# Altium schematic sheet Sensor inputs.SchDoc("Sensor inputs")
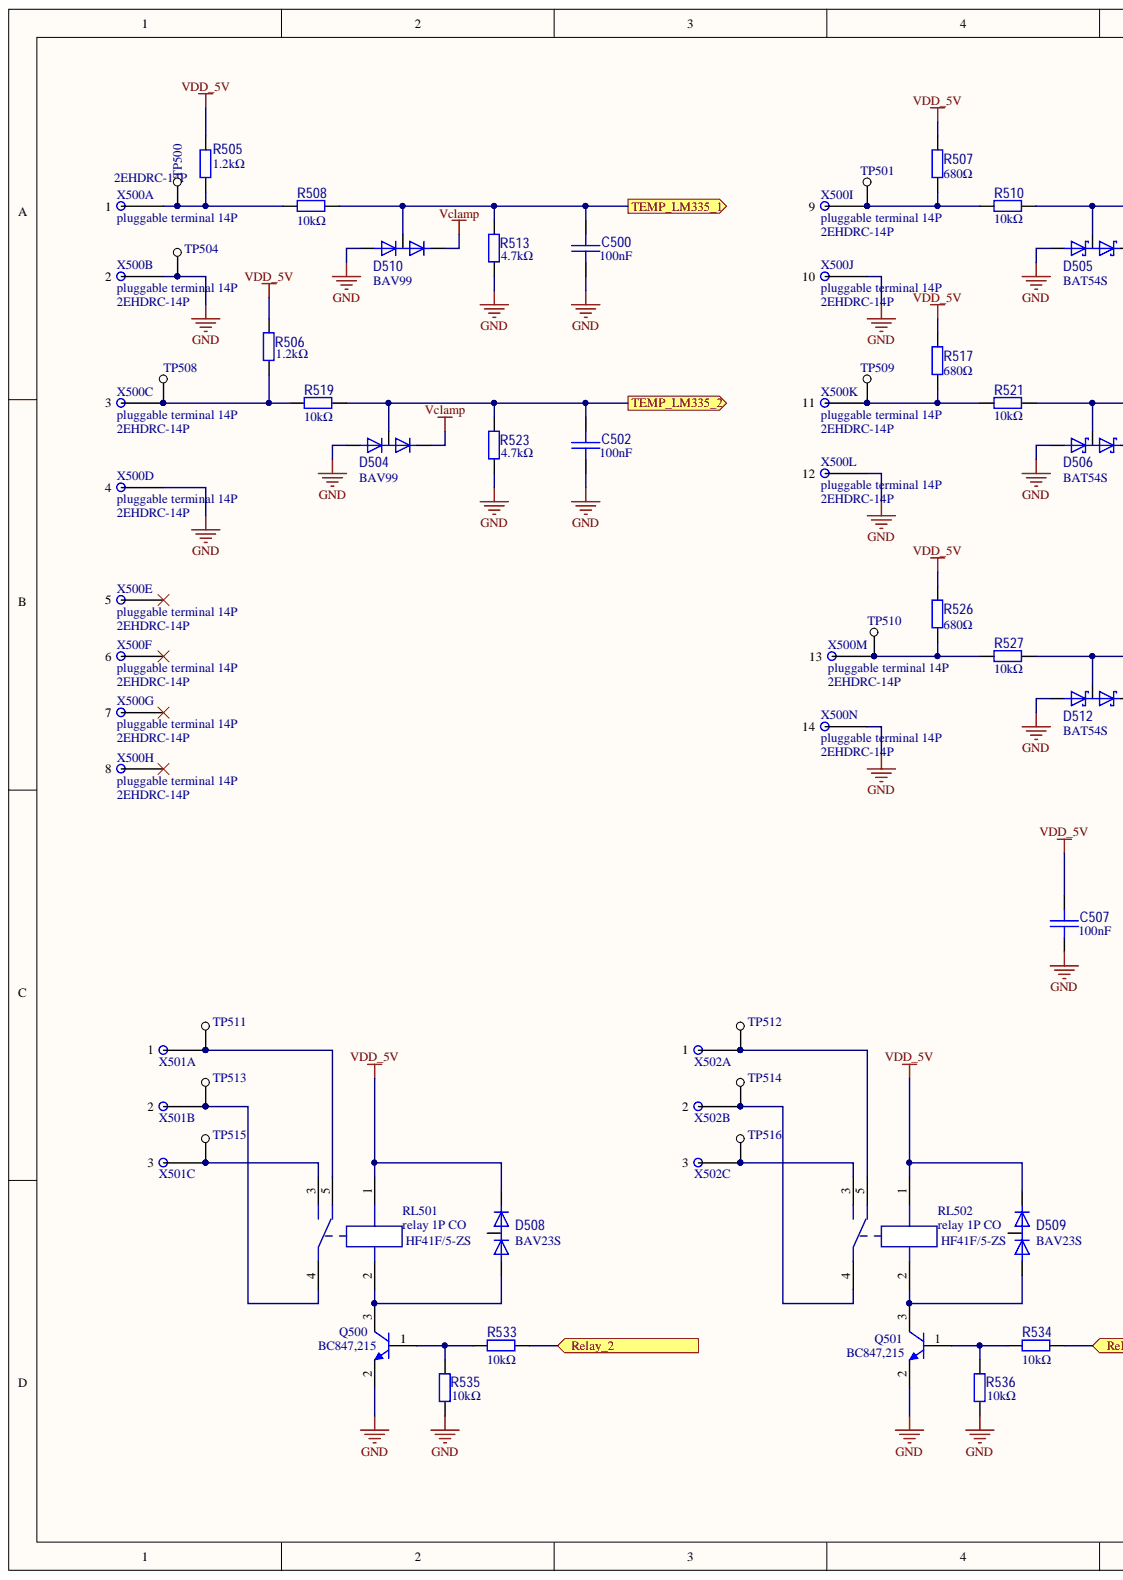
[diagram p0: Sensor inputs.SchDoc, left]
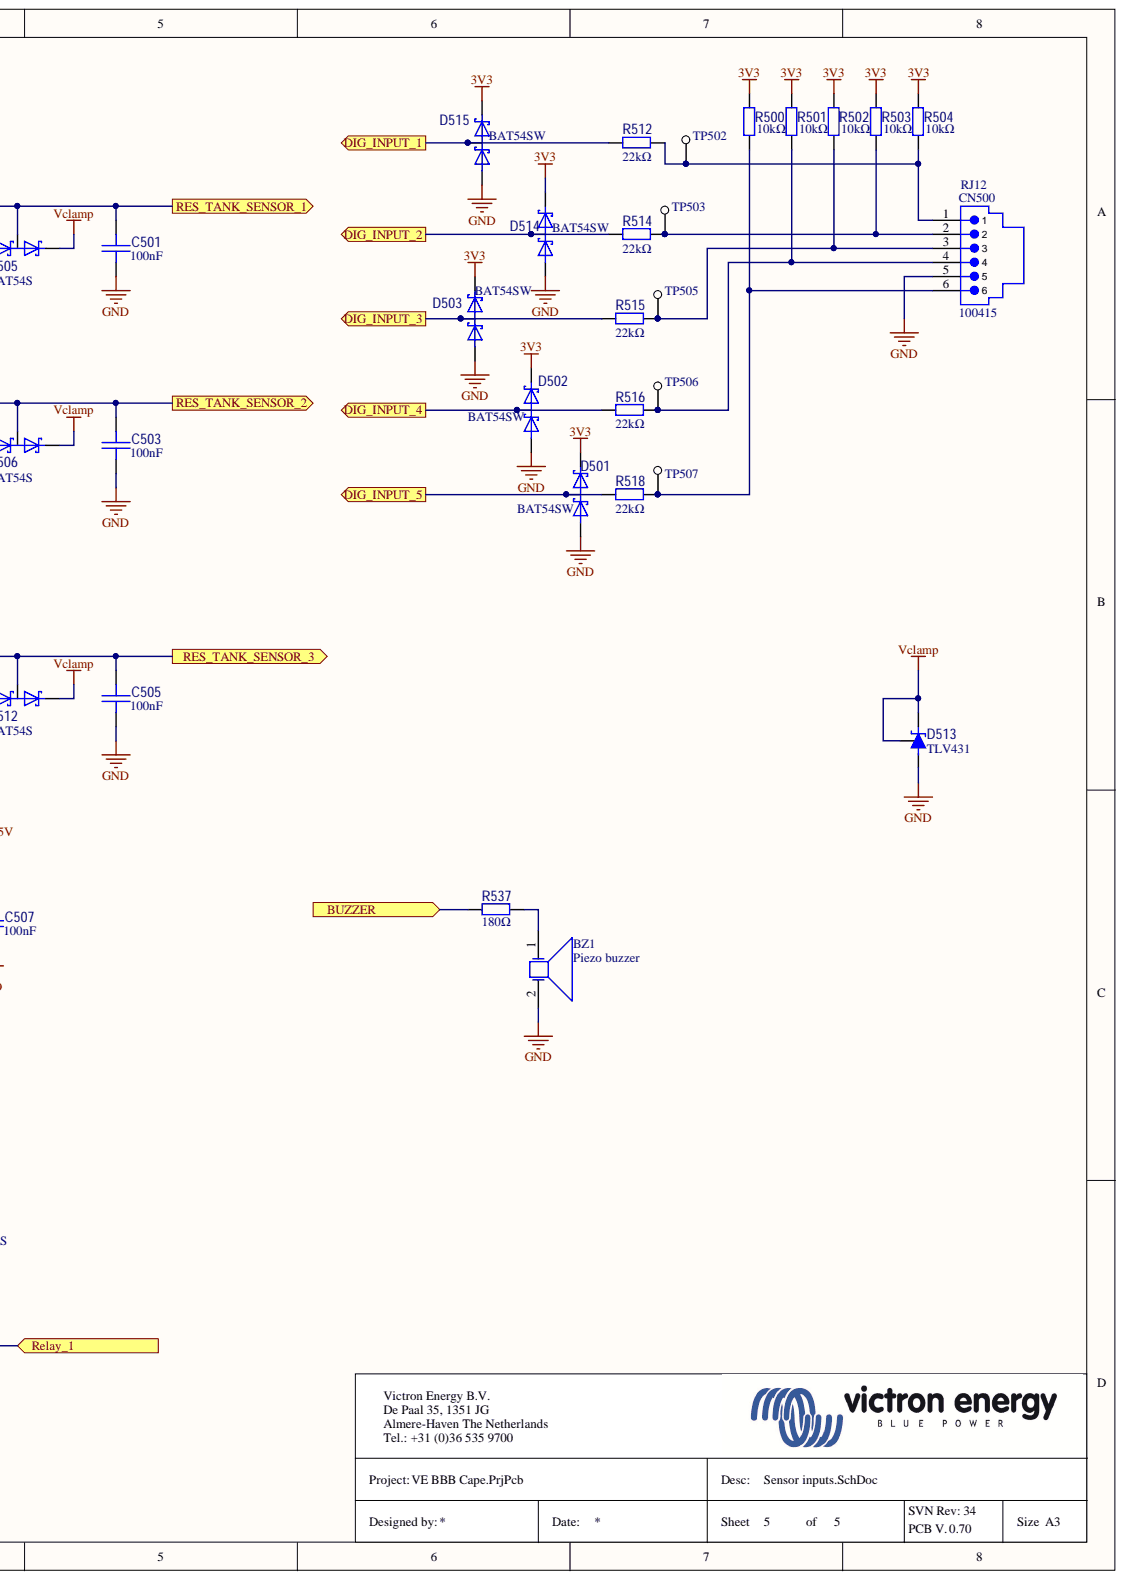
[diagram p1: Sensor inputs.SchDoc, right]

## Parts
BZ1  Piezo buzzer  [TH_BUZ_12.5mm]
C500  value 100nF  [C0805_R]  (hidden comment: 1035)
C501  value 100nF  [C0805_R]  (hidden comment: 1035)
C502  value 100nF  [C0805_R]  (hidden comment: 1035)
C503  value 100nF  [C0805_R]  (hidden comment: 1035)
C505  value 100nF  [C0805_R]  (hidden comment: 1035)
C507  value 100nF  [C0805_R]  (hidden comment: 1035)
CN500  100415  value RJ12  [Molex950012661]
D501  MAN_PARTNUMBER: BAT54SW  [SOT323R]  (hidden comment: Value)
D502  MAN_PARTNUMBER: BAT54SW  [SOT323R]  (hidden comment: Value)
D503  MAN_PARTNUMBER: BAT54SW  [SOT323R]  (hidden comment: Value)
D504  BAV99  [SOT23_R]
D505  BAT54S  [SOT23_R]
D506  BAT54S  [SOT23_R]
D508  BAV23S  [SOT23_R]
D509  BAV23S  [SOT23_R]
D510  BAV99  [SOT23_R]
D512  BAT54S  [SOT23_R]
D513  TLV431  [SOT23_R]
D514  MAN_PARTNUMBER: BAT54SW  [SOT323R]  (hidden comment: Value)
D515  MAN_PARTNUMBER: BAT54SW  [SOT323R]  (hidden comment: Value)
Q500  value BC847,215  [SOT23_R]  (hidden comment: 100435)
Q501  value BC847,215  [SOT23_R]  (hidden comment: 100435)
R500  value 10kΩ  [R0805_R]  (hidden comment: 396)
R501  value 10kΩ  [R0805_R]  (hidden comment: 396)
R502  value 10kΩ  [R0805_R]  (hidden comment: 396)
R503  value 10kΩ  [R0805_R]  (hidden comment: 396)
R504  value 10kΩ  [R0805_R]  (hidden comment: 396)
R505  value 1.2kΩ  [R0805_R]  (hidden comment: 374)
R506  value 1.2kΩ  [R0805_R]  (hidden comment: 374)
R507  value 680Ω  [R0805_R]  (hidden comment: 368)
R508  value 10kΩ  [R0805_R]  (hidden comment: 396)
R510  value 10kΩ  [R0805_R]  (hidden comment: 396)
R512  value 22kΩ  [R0805_R]  (hidden comment: 404)
R513  value 4.7kΩ  [R0805_R]  (hidden comment: 384)
R514  value 22kΩ  [R0805_R]  (hidden comment: 404)
R515  value 22kΩ  [R0805_R]  (hidden comment: 404)
R516  value 22kΩ  [R0805_R]  (hidden comment: 404)
R517  value 680Ω  [R0805_R]  (hidden comment: 368)
R518  value 22kΩ  [R0805_R]  (hidden comment: 404)
R519  value 10kΩ  [R0805_R]  (hidden comment: 396)
R521  value 10kΩ  [R0805_R]  (hidden comment: 396)
R523  value 4.7kΩ  [R0805_R]  (hidden comment: 384)
R526  value 680Ω  [R0805_R]  (hidden comment: 368)
R527  value 10kΩ  [R0805_R]  (hidden comment: 396)
R533  value 10kΩ  [R0805_R]  (hidden comment: 396)
R534  value 10kΩ  [R0805_R]  (hidden comment: 396)
R535  value 10kΩ  [R0805_R]  (hidden comment: 396)
R536  value 10kΩ  [R0805_R]  (hidden comment: 396)
R537  value 180Ω  [R0805_R]  (hidden comment: 354)
RL501  relay 1P CO  value HF41F/5-ZS  VENDOR_STOCK_NR: HF41F/5-ZS  [PNSC]
RL502  relay 1P CO  value HF41F/5-ZS  [PNSC]
TP500  CN1  [testpad_round_1mm]  (value not drawn)  (no BOM)
TP501  CN1  [testpad_round_1mm]  (value not drawn)  (no BOM)
TP502  CN1  [testpad_round_1mm]  (value not drawn)  (no BOM)
TP503  CN1  [testpad_round_1mm]  (value not drawn)  (no BOM)
TP504  CN1  [testpad_round_1mm]  (value not drawn)  (no BOM)
TP505  CN1  [testpad_round_1mm]  (value not drawn)  (no BOM)
TP506  CN1  [testpad_round_1mm]  (value not drawn)  (no BOM)
TP507  CN1  [testpad_round_1mm]  (value not drawn)  (no BOM)
TP508  CN1  [testpad_round_1mm]  (value not drawn)  (no BOM)
TP509  CN1  [testpad_round_1mm]  (value not drawn)  (no BOM)
TP510  CN1  [testpad_round_1mm]  (value not drawn)  (no BOM)
TP511  CN1  [testpad_round_1mm]  (value not drawn)  (no BOM)
TP512  CN1  [testpad_round_1mm]  (value not drawn)  (no BOM)
TP513  CN1  [testpad_round_1mm]  (value not drawn)  (no BOM)
TP514  CN1  [testpad_round_1mm]  (value not drawn)  (no BOM)
TP515  CN1  [testpad_round_1mm]  (value not drawn)  (no BOM)
TP516  CN1  [testpad_round_1mm]  (value not drawn)  (no BOM)
X500  pluggable terminal 14P  value 2EHDRC-14P  [2EHDRC-14P]  (parts A,B,C,D,E,F,G,H,I,J,K,L,M,N)
X501  pluggable terminal 3P  [2EHDRC-3P]  (value not drawn)  (parts A,B,C)
X502  pluggable terminal 3P  [2EHDRC-3P]  (value not drawn)  (parts A,B,C)

## Ports
BUZZER, DIG_INPUT_1, DIG_INPUT_2, DIG_INPUT_3, DIG_INPUT_4, DIG_INPUT_5, Relay_1, Relay_2, RES_TANK_SENSOR_1, RES_TANK_SENSOR_2, RES_TANK_SENSOR_3, TEMP_LM335_1, TEMP_LM335_2

## Nets
3V3: D501.2 D502.2 D503.2 D514.2 D515.2 R500.2 R501.2 R502.2 R503.2 R504.2
GND: BZ1.2 C500.2 C501.2 C502.2 C503.2 C505.2 C507.2 CN500.5 D501.1 D502.1 D503.1 D504.1 D505.1 D506.1 D510.1 D512.1 D513.3 D514.1 D515.1 Q500.2 Q501.2 R513.2 R523.2 R535.1 R536.1 TP504.1 X500.2 X500.4 X500.10 X500.12 X500.14
Vclamp: D504.2 D505.2 D506.2 D510.2 D512.2 D513.1 D513.2
VDD_5V: C507.1 D508.2 D509.2 R505.1 R506.1 R507.1 R517.1 R526.1 RL501.1 RL502.1
BUZZER: R537.1 ; port BUZZER
DIG_INPUT_1: D515.3 R512.1 ; port DIG_INPUT_1
DIG_INPUT_2: D514.3 R514.1 ; port DIG_INPUT_2
DIG_INPUT_3: D503.3 R515.1 ; port DIG_INPUT_3
DIG_INPUT_4: D502.3 R516.1 ; port DIG_INPUT_4
DIG_INPUT_5: D501.3 R518.1 ; port DIG_INPUT_5
Relay_1: R534.2 ; port Relay_1
Relay_2: R533.2 ; port Relay_2
RES_TANK_SENSOR_1: C501.1 D505.3 R510.2 ; port RES_TANK_SENSOR_1
RES_TANK_SENSOR_2: C503.1 D506.3 R521.2 ; port RES_TANK_SENSOR_2
RES_TANK_SENSOR_3: C505.1 D512.3 R527.2 ; port RES_TANK_SENSOR_3
TEMP_LM335_1: C500.1 D510.3 R508.2 R513.1 ; port TEMP_LM335_1
TEMP_LM335_2: C502.1 D504.3 R519.2 R523.1 ; port TEMP_LM335_2
NetBZ1_1: BZ1.1 R537.2
NetCN500_1: CN500.1 R504.1 R512.2 TP502.1
NetCN500_2: CN500.2 R503.1 R514.2 TP503.1
NetCN500_3: CN500.3 R502.1 R515.2 TP505.1
NetCN500_4: CN500.4 R501.1 R516.2 TP506.1
NetCN500_6: CN500.6 R500.1 R518.2 TP507.1
NetD508_1: D508.1 Q500.3 RL501.2
NetD509_1: D509.1 Q501.3 RL502.2
NetQ500_1: Q500.1 R533.1 R535.2
NetQ501_1: Q501.1 R534.1 R536.2
NetR505_2: R505.2 R508.1 TP500.1 X500.1
NetR506_2: R506.2 R519.1 TP508.1 X500.3
NetR507_2: R507.2 R510.1 TP501.1 X500.9
NetR517_2: R517.2 R521.1 TP509.1 X500.11
NetR526_2: R526.2 R527.1 TP510.1 X500.13
NetRL501_3: RL501.3 TP515.1 X501.3
NetRL501_4: RL501.4 TP513.1 X501.2
NetRL501_5: RL501.5 TP511.1 X501.1
NetRL502_3: RL502.3 TP516.1 X502.3
NetRL502_4: RL502.4 TP514.1 X502.2
NetRL502_5: RL502.5 TP512.1 X502.1

Not connected: D508 3; D509 3; X500 5 6 7 8

## Library data of the parts
BZ1 = 101565: MANUFACTURER: Murata; MAN_PARTNUMBER: PKM13EPYH4000-A0; Database Table Name: Mechanical; Cls: MECH; Value: Piezo buzzer; Supplier Price 1: 0,267; Supplier Part Number 1: 490-4698-ND; Supplier 1: Digikey; PartID: 101565; Part: 101565; Description: Piezo buzzer; Component Kind: Standard; Library Name: VictronAltiumComponents.SVNDBLib
C500-C503 C505 C507 = 1035: Cap, SMD 0805, ceramic, X7R, 100nF, 50V, 10%, Yageo; MAN_PARTNUMBER: CC0805KPX7R9BB104; INDIC_PARTNO: CSE100NF0805YAGY; Tol: 10,00%; Shape: 805; INDIC_PRICE: 0,068; Database Table Name: AllComponents; Voltage: 50V; Supplier Price 1: 0,005; Supplier Part Number 1: 603-CC805KPX7R9BB104; Supplier 1: Mouser; PartID: 1035; Part: 1035; MANUFACTURER: Yageo; Component Kind: Standard; Cls: CAP; Library Name: VictronAltiumComponents.SVNDBLib
CN500 = 100415: RJ12; Part_Description: RJ12 Side entry; MAN_PARTNUMBER: 955012661; VENDOR_STOCK_NR: WM5570-ND; VENDOR_PRICE: 0.45312; VENDOR: Digikey; Database Table Name: COMPDATA$; Cls: CON; Part: 100415; Component Kind: Standard; Library Name: Data Base Librarys.DbLib
D501-D503 D514 D515 = 101810: Diode Schottky BAT54SW; MANUFACTURER: NXP; Shape: SOT323; Cls: DIO; Supplier Price 1: 0,03698; Supplier Part Number 1: 568-1615-2-ND; Supplier 1: Digikey; PartID: 101810; Part: 101810; Database Table Name: Diode; Component Kind: Standard; Library Name: VictronAltiumComponents.SVNDBLib
D504 D510 = 4001: Diode, SMD, BAV99; MANUFACTURER: Fairchild; IRRICHI_PARTNO: 2403327470000; INDIC_PARTNO: DDSANBAV99YN/XX; INCAP_PARTNO: 1009100528; Shape: SOT23; IRRICHI_PRICE: 0,0171; INDIC_PRICE: 0,026; INCAP_PRICE: 0,0153; Database Table Name: AllComponents; Cls: DIO; Value: BAV99; Supplier Price 1: 0,02091; Supplier Part Number 1: BAV99LT1GOSTR-ND; Supplier 1: Digikey; PartID: 4001; Part: 4001; MAN_PARTNUMBER: BAV99; Component Kind: Standard; Library Name: VictronAltiumComponents.SVNDBLib
D505 D506 D512 = 4004: Diode, SMD, 30V, 200mA, BAT54S, SOT23, dual; MANUFACTURER: NXP; MAN_PARTNUMBER: BAT54S,215; IRRICHI_PARTNO: 2403289450000; INCAP_PARTNO: 1009100230; ACM_PARTNO: 9649; IRRICHI_PRICE: 0,016; INCAP_PRICE: 0,0095; Cls: DIO; ACM_PRICE: 0,0146; Value: BAT54S; Supplier Price 1: 0,03; Supplier Part Number 1: 1081194; Supplier 1: Farnell; Shape: SOT23; PartID: 4004; Part: 4004; Database Table Name: Diode; Component Kind: Standard; Library Name: VictronAltiumComponents.SVNDBLib
D508 D509 = 100417: MAN_PARTNUMBER: BAV23S-7-F; VENDOR_STOCK_NR: BAV23S-FDITR-ND; VENDOR_PRICE: 0.0621; VENDOR: Digikey; Database Table Name: COMPDATA$; Cls: D; Value: BAV23S; Part_Description: BAV23S; Part: 100417; Component Kind: Standard; Library Name: Data Base Librarys.DbLib
D513 = 101578: Voltage reference, 1.24V, 1%, 80µA; MANUFACTURER: DIODES INC; MAN_PARTNUMBER: TLV431AFTA; Shape: SOT23; Database Table Name: AllComponents; Cls: IC; Value: TLV431; Supplier Price 1: 0,204; Supplier Part Number 1: 595	TLV431AFTACT-ND; Supplier 1: Digikey; PartID: 101578; Part: 101578; Component Kind: Standard; Library Name: VictronAltiumComponents.SVNDBLib
Q500 Q501 = 100435: Transistor, NPN, 45V,  100mA, SOT23, BC847C, NXP; MAN_PARTNUMBER: BC847C215; INDIC_PARTNO: TRSABC847CNPYNXP; INDIC_PRICE: 0,050; Database Table Name: AllComponents; Cls: TRAN; Voltage: 45V; Supplier Price 1: 0,026; Supplier Part Number 1: 771-BC847C-T/R; Supplier 1: Mouser; Shape: SOT23; PartID: 100435; Part: 100435; MANUFACTURER: NXP; Current: 100mA; Component Kind: Standard; Library Name: VictronAltiumComponents.SVNDBLib
R500-R504 R508 R519 R533-R536 = 396: Part_Description: RES,MF,10K  0.125W 1%0805; MANUFACTURER: YAGEO; MAN_PARTNUMBER: RC0805FR-0710KL; INDIC_PARTNO: RSCE00010KFB7YAY; INCAP_PARTNO: 1035031290; VENDOR_STOCK_NR: 603-RC0805FR-0710KL; VENDOR_PRICE: 0.003; VENDOR: Mouser; Tol: 0.01; Shape: 805; Power: 0,125W; INDIC_PRICE: 0.0018; INCAP_PRICE: 0.0014; Database Table Name: COMPDATA$; Cls: RES; Part: 396; ComponentLink1Description: \datasheets\Yageo-RC0805FR-0710KL-datasheet.pdf; Component Kind: Standard; Library Name: Data Base Librarys.DbLib
R505 R506 = 374: Part_Description: RES,MF,1k2, 0.125W 1% 0805; MANUFACTURER: YAGEO; MAN_PARTNUMBER: RC0805FR-071K2L; VENDOR_STOCK_NR: 603-RC0805FR-071K2L; VENDOR_PRICE: 0.003; VENDOR: Mouser; Tol: 0.01; Shape: 805; Power: 0,125W; INCAP_PRICE: 0.0014; Database Table Name: COMPDATA$; Cls: RES; Part: 374; ComponentLink1Description: \datasheets\Yageo-RC0805FR-0710KL-datasheet.pdf; Component Kind: Standard; Library Name: Data Base Librarys.DbLib
R507 R517 R526 = 368: Res, SMD 0805, MF, 680E, 0.125W 1% 0805; MANUFACTURER: YAGEO; MAN_PARTNUMBER: RC0805FR-07680RL; Tol: 1,00%; Shape: 0805; Power: 0,125W; INCAP_PRICE: 0,0009; Database Table Name: AllComponents; PartID: 368; Part: 368; ComponentLink1Description: \datasheets\Yageo-RC0805FR-0710KL-datasheet.pdf; Component Kind: Standard; Cls: RES; Library Name: VictronAltiumComponents.SVNDBLib
R510 R521 R527 = 396: Res, SMD 0805, MF, 10K  0.125W 1%0805; MANUFACTURER: YAGEO; MAN_PARTNUMBER: RC0805FR-0710KL; INDIC_PARTNO: RSCE00010KFB7YAY; INCAP_PARTNO: 1035031290; Tol: 1,00%; Shape: 0805; Power: 0,125W; INDIC_PRICE: 0,002; INCAP_PRICE: 0,0009; Database Table Name: AllComponents; Supplier Price 1: 0,003; Supplier Part Number 1: 603-RC0805FR-0710KL; Supplier 1: Mouser; PartID: 396; Part: 396; ComponentLink1Description: \datasheets\Yageo-RC0805FR-0710KL-datasheet.pdf; Component Kind: Standard; Cls: RES; Library Name: VictronAltiumComponents.SVNDBLib
R512 R514-R516 R518 = 404: Part_Description: RES,MF,22K   0.125W 1% 0805; MANUFACTURER: YAGEO; MAN_PARTNUMBER: RC0805FR-0722KL; INDIC_PARTNO: RSCEE0022KF97MUY; INCAP_PARTNO: 1035031293; VENDOR_STOCK_NR: 603-RC0805FR-0722KL; VENDOR_PRICE: 0.003; VENDOR: Mouser; Tol: 0.01; Shape: 805; Power: 0,125W; INDIC_PRICE: 0.0023; INCAP_PRICE: 0.0014; Database Table Name: COMPDATA$; Cls: RES; Part: 404; ComponentLink1Description: \datasheets\Yageo-RC0805FR-0710KL-datasheet.pdf; Component Kind: Standard; Library Name: Data Base Librarys.DbLib
R513 R523 = 384: Part_Description: RES,MF,4K7, 0.125W 1% 0805; MANUFACTURER: YAGEO; MAN_PARTNUMBER: RC0805FR-074K7L; INDIC_PARTNO: RSCEO4K700FB6YAY; VENDOR_STOCK_NR: 603-RC0805FR-074K7L; VENDOR_PRICE: 0.003; VENDOR: Mouser; Tol: 0.01; Shape: 805; Power: 0,125W; INDIC_PRICE: 0.0076; INCAP_PRICE: 0.0014; Database Table Name: COMPDATA$; Cls: RES; Part: 384; ComponentLink1Description: \datasheets\Yageo-RC0805FR-0710KL-datasheet.pdf; Component Kind: Standard; Library Name: Data Base Librarys.DbLib
R537 = 354: Part_Description: RES,MF,180E, 0.125W 1% 0805; MANUFACTURER: YAGEO; MAN_PARTNUMBER: RC0805FR-07180RL; Tol: 0.01; Shape: 805; Power: 0,125W; INCAP_PRICE: 0.0014; Database Table Name: COMPDATA$; Cls: RES; Part: 354; ComponentLink1Description: \datasheets\Yageo-RC0805FR-0710KL-datasheet.pdf; Component Kind: Standard; Library Name: Data Base Librarys.DbLib
RL501 = 100423: Part_Description: Hongfa SIL form 1C relay; MANUFACTURER: Hongfa; VENDOR_PRICE: 1.25; VENDOR: Future; Database Table Name: COMPDATA$; Part: 100423; MAN_PARTNUMBER: HF41F/5-ZS; Description: relay 1P CO; Component Kind: Standard; Cls: RELAY; Library Name: Data Base Librarys.DbLib
RL502 = 100423: Part_Description: Hongfa SIL form 1C relay; MANUFACTURER: Hongfa; VENDOR_STOCK_NR: HF41F/5-ZS; VENDOR_PRICE: 1.25; VENDOR: Future; Value: HF41F/5-ZS; Database Table Name: COMPDATA$; Part: 100423; MAN_PARTNUMBER: HF41F/5-ZS; Description: relay 1P CO; Component Kind: Standard; Cls: RELAY; Library Name: Data Base Librarys.DbLib
TP500-TP516 = 100320: Testpad, Round, D=1mm; Database Table Name: Connector; Cls: CON; PartID: 100320; Part: 100320; Library Name: VictronAltiumComponents.SVNDBLib
X500 = 101572: 2EHDRC-14P; MANUFACTURER: 2EHDRC-14P + 2ESDV-14P; Database Table Name: Connector; Cls: CON; Shape: 2EHDRC-14P; PartID: 101572; Part: 101572; Component Kind: Standard; Library Name: VictronAltiumComponents.SVNDBLib
X501 X502 = 101570: 2EHDRC-3P; MANUFACTURER: 2EHDRC-3P + 2ESDV-3P; Database Table Name: AllComponents; Cls: CON; PartID: 101570; Part: 101570; Component Kind: Standard; Library Name: VictronAltiumComponents.SVNDBLib
